# Altium schematic sheet top_blank.SchDoc
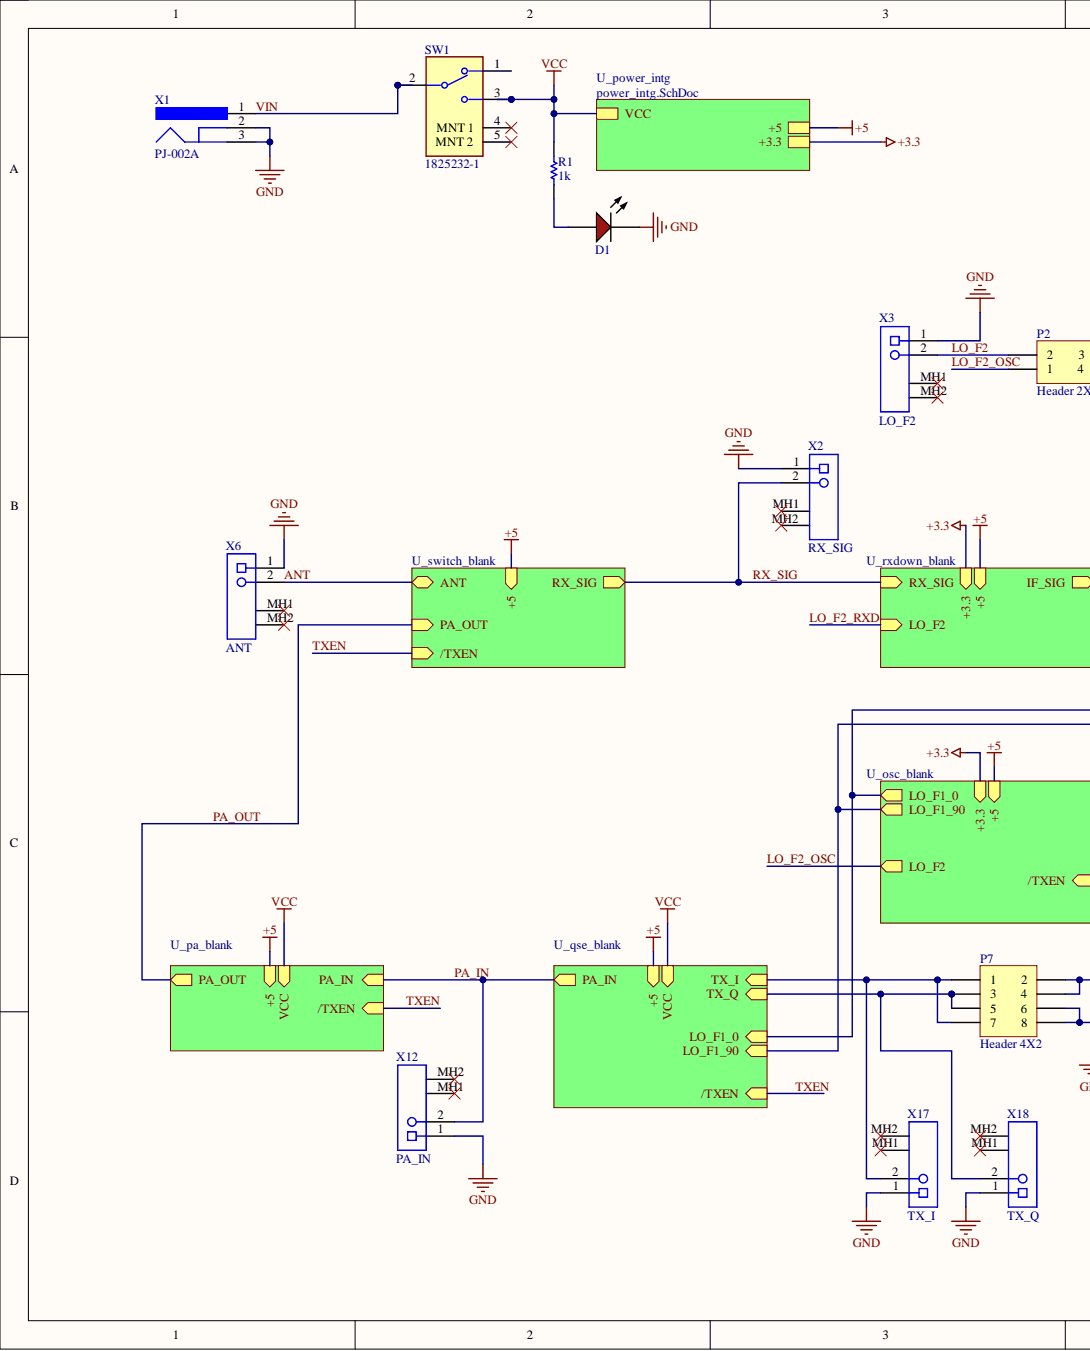
[diagram p0: top_blank.SchDoc, left]
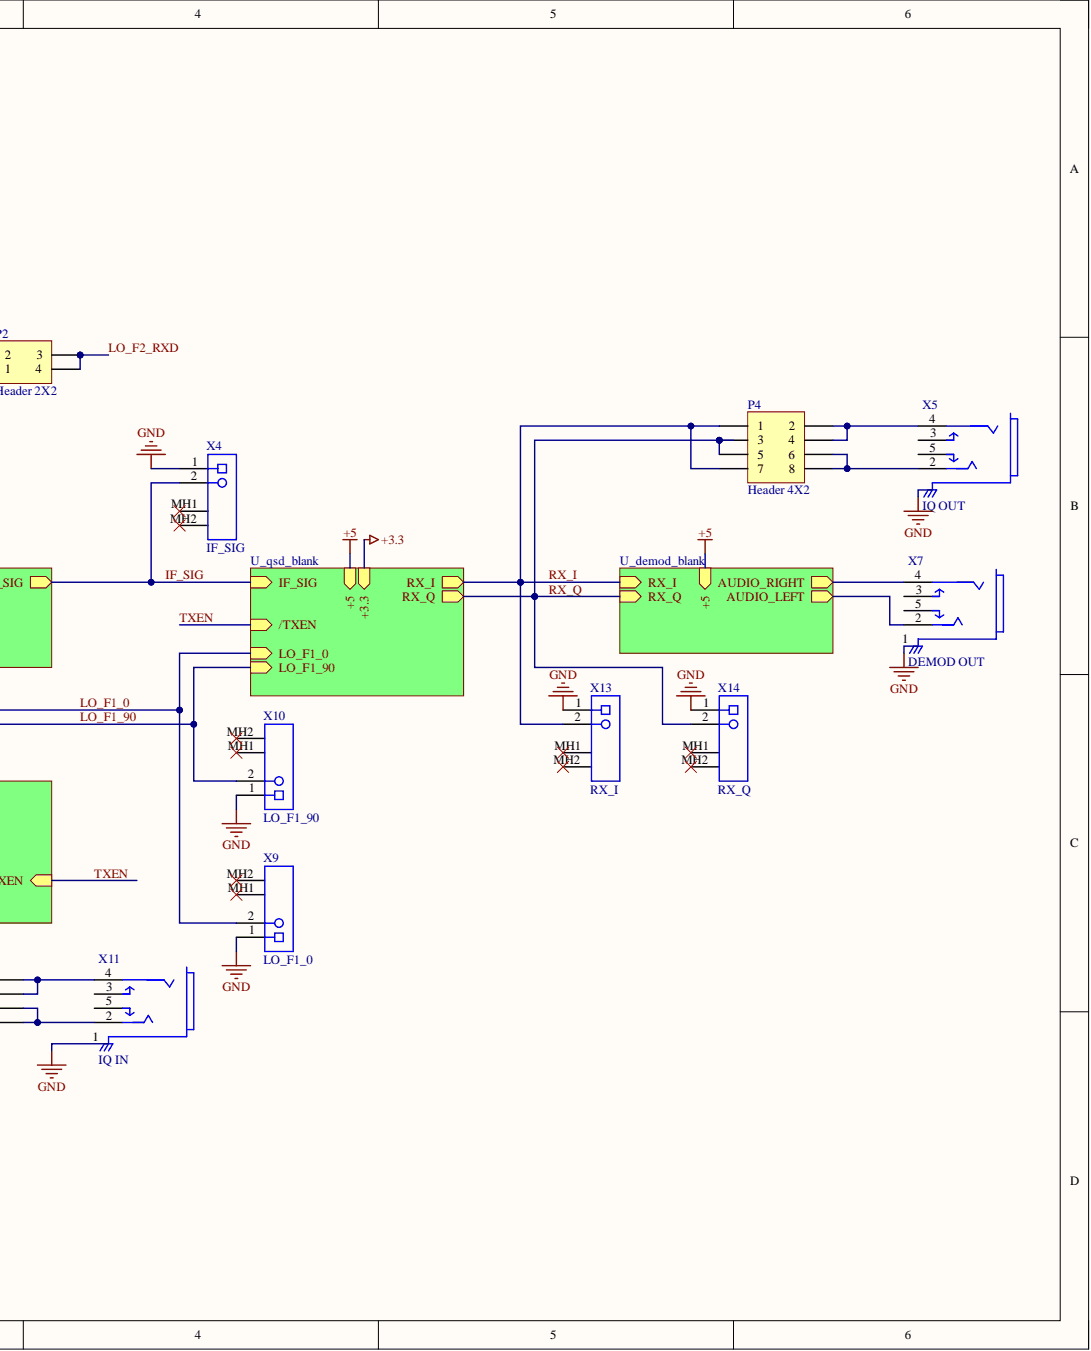
[diagram p1: top_blank.SchDoc, right]

## Parts
D1  HLMP-3301  [AVAG-HLMP-3301-2]  (value not drawn)
P2  Header 2X2  [HDR2X2]
P4  Header 4X2  [HDR2X4]
P7  Header 4X2  [HDR2X4]
R1  value 1k  [AXIAL-0.4]  (hidden comment: Res1)
SW1  1825232-1  [FP-1825232-1-MFG]
X1  PJ-002A  [CUI-PJ-002A_V]
X2  RX_SIG  [TECO-1-1337543-0_V]
X3  LO_F2  [TECO-1-1337543-0_V]
X4  IF_SIG  [TECO-1-1337543-0_V]
X5  IQ OUT  [FP-35RAPC4BV4-MFG]
X6  ANT  [TECO-1-1337543-0_V]
X7  DEMOD OUT  [FP-35RAPC4BV4-MFG]
X9  LO_F1_0  [TECO-1-1337543-0_V]
X10  LO_F1_90  [TECO-1-1337543-0_V]
X11  IQ IN  [FP-35RAPC4BV4-MFG]
X12  PA_IN  [TECO-1-1337543-0_V]
X13  RX_I  [TECO-1-1337543-0_V]
X14  RX_Q  [TECO-1-1337543-0_V]
X17  TX_I  [TECO-1-1337543-0_V]
X18  TX_Q  [TECO-1-1337543-0_V]

## Nets
GND: D1.1 X1.2 X1.3 X2.1 X3.1 X4.1 X5.1 X6.1 X7.1 X9.1 X10.1 X11.1 X12.1 X13.1 X14.1 X17.1 X18.1
VCC: R1.2 SW1.3
ANT: X6.2
IF_SIG: X4.2
LO_F1_0: X9.2
LO_F1_90: X10.2
LO_F2: P2.2 X3.2
LO_F2_OSC: P2.1
LO_F2_RXD: P2.3 P2.4
PA_IN: X12.2
RX_I: P4.1 P4.7 X13.2
RX_Q: P4.3 P4.5 X14.2
RX_SIG: X2.2
VIN: SW1.2 X1.1
NetD1_2: D1.2 R1.1
NetP4_2: P4.2 P4.4 X5.4
NetP4_6: P4.6 P4.8 X5.2
NetP7_1: P7.1 P7.7 X17.2
NetP7_2: P7.2 P7.4 X11.4
NetP7_3: P7.3 P7.5 X18.2
NetP7_6: P7.6 P7.8 X11.2
+3.3:
+5:
PA_OUT:
TXEN:

Not connected: SW1 1 4(MNT_1) 5(MNT_2); X2 MH1 MH2; X3 MH1 MH2; X4 MH1 MH2; X5 3 5; X6 MH1 MH2; X7 2 3 4 5; X9 MH1 MH2; X10 MH1 MH2; X11 3 5; X12 MH1 MH2; X13 MH1 MH2; X14 MH1 MH2; X17 MH1 MH2; X18 MH1 MH2

## Library data of the parts
D1 = CMP-2000-06411-1: T-1 3/4 (5 mm) Diffused LED Lamp, 1.9 V, -40 to 100 degC, 2-Pin THD, RoHS, Bulk; Manufacturer: Avago; Chip Material: GaP; DELETED: Compliant; Dominant Wave Length: 626nm; Emitting Color: Red; Forward Current: 25mA; Forward Voltage: 2.4V; High Brightness: No; Lens Type: ColoredDiffused; Lum Intensity: 5.4mcd; Main Category: StandardLED; Number of  Elements: 1; Peak Wavelength: 635nm; Power Dissipation: 85W; Product Depth (mm): 6.1mm; Product Height (mm): 9.19mm; Reverse Voltage: 5V; Shape Type: Circular; Test Current (It): 10mA; Type: Uni-Color; Viewing Angle: 60deg; Component Kind: Standard; Datasheet URL: http://www.avagotech.com/docs/AV02-1558EN; Datasheet Version: 03/2015; Lens Dimensions: 5.08x5.08x8.3mm; Library Name: Altium Content Vault; Luminous Intensity: 5.4mcd; Manufacturer URL: http://www.avagotech.com/; Mounting: ThroughHole; Mounting Technology: ThroughHole; Operating Temp Range: -40Cto100C; Operating Temperature Classification: Industrial; Package Description: 2-Pin Through Hole LED, Dia 5.85 mm; Package Reference: HLMP-3301; Package Type: T-13/4; Packaging: TapeandReel; Pin Count: 2; Product Length (mm): 6.1mm; Rad Hardened: No
P2 = Header 2X2: Header, 2-Pin, Dual row; Component Kind: Standard; LatestRevisionDate: 17-Jul-2002; LatestRevisionNote: Re-released for DXP Platform.; Library Name: Miscellaneous Connectors.IntLib; Signal Integrity: Connector; Publisher: Altium Limited
P4 P7 = Header 4X2: Header, 4-Pin, Dual row; Component Kind: Standard; LatestRevisionDate: 17-Jul-2002; LatestRevisionNote: Re-released for DXP Platform.; Library Name: Miscellaneous Connectors.IntLib; Signal Integrity: Connector; Publisher: Altium Limited
R1 = Res1: Resistor; Component Kind: Standard; LatestRevisionDate: 17-Jul-2002; LatestRevisionNote: Re-released for DXP Platform.; Library Name: Miscellaneous Devices.IntLib; PackageDescription: Axial Device, Thru-Hole; 2 Leads; 0.3 in Pin Spacing; PackageReference: AXIAL-0.3; Published: 8-Jun-2000; Signal Integrity: Res; Simulation: RESISTOR; Publisher: Altium Limited
SW1 = CMP-03405-000001-1: SWITCH SLIDE SPDT 200MA 30V; Manufacturer: TE Connectivity; Contact Current Rating: 0.2A; Contact Resistance: 20mΩ; Electromechanical Life: 10000Cycles; Operating Force: 200gf; Automotive: No; Category: Switch; Component Kind: Standard; ComponentLink2Description: Datasheet; ComponentLink2URL: https://www.te.com/commerce/DocumentDelivery/DDEController?Action=showdoc&DocId=Customer+Drawing%7F1825232%7FB2%7Fpdf%7FEnglish%7FENG_CD_1825232_B2.pdf%7F1825232-1; Device Class L1: Electromechanical; Device Class L2: Switches; Device Class L3: Slide Switches; Digikey Description: SWITCH SLIDE SPDT 200MA 30V; Height: 3.7mm; Lead Free: Yes; Library Name: Altium Content Vault; Manufacturer Part Number: 1825232-1; Mount: Through Hole; Mouser Description: Slide Switches SLIDE SWITCH SPDT Right Angle; Octopart: https://octopart.com/1825232-1-te+connectivity-45209882; Package: 1825232-1; RoHS: TRUE; Temperature: -20°C to +70°C; Temperature Range High: +70°C; Temperature Range Low: -20°C; Throw Configuration: SPDT; Voltage Rating Dc: 30V
X1 = CMP-2000-06895-1: Through Hole Right Angle DC Power Jack, 2.5 A, 2 mm Center Pin, 3 Position, -25 to 85 degC, RoHS, Bulk; Manufacturer: CUIInc.; Brand: CUI; Current Rating: 2.5A; Inside Contact Diameter: 2mm; Insulation Resistance: 50MOhms; Outside Contact Diameter: 6.5mm; Voltage Rating: 24V; Component Kind: Standard; Connector Type: DCPowerJack; Contact Gender: Male; Contact Material: Copper; Contact Plating: Nickel; Datasheet URL: http://www.cui.com/product/resource/pj-002a.pdf; Datasheet Version: Rev. 1.03, 04/2016; Factory Pack Quantity: 500; Flammability Rating: UL94V-0; Housing Material: PolybutyleneTerephthalate(PBT); Library Name: Altium Content Vault; Manufacturer URL: http://www.cui.com/; Mounting Angle: Right; Mounting Style: ThroughHole; Mounting Technology: ThroughHole; Operating Temperature Range: -25Cto+85C; Package Description: 3-Pin Through Hole Device, Body 14.4 x 9 mm, Height 11 mm; Package Reference: PJ-002A; Product: Jacks; Product Category: DCPowerConnectors; RoHS: True; Termination Style: ThroughHole
X2-X4 X6 X9 X10 X12-X14 X17 X18 = CMP-2000-05853-1: Female Connector, Pitch 2.54 mm, 1 x 2 Position, Height 15.8 mm, Tail Length 3.8 mm,   -55 to 85 degC, RoHS, Bulk; Manufacturer: TE Connectivity; Coaxial Termination: Solder; Connector Body Style: RightAngleJack; Connector Mounting: PCBMount; Connector Type: BNCCoaxial; Frequency Max: 4GHz; Impedance: 50ohm; SVHC: ToBeAdvised; Coaxial Cable Types: -; Component Kind: Standard; Contact Material: Brass; Contact Plating: GoldPlatedContacts; Datasheet URL: http://www.te.com/commerce/DocumentDelivery/DDEController?Action=showdoc&DocId=Customer+Drawing%7F1337543%7FG%7Fpdf%7FEnglish%7FENG_CD_1337543_G.pdf%7F1-1337543-0; Datasheet Version: Rev. G, 12/2012; Library Name: Altium Content Vault; Manufacturer URL: http://www.te.com/; Mounting Technology: ThroughHole; Package Description: Female Connector, Pitch 2.54 mm, 1 x 2 Position, Height 15.8 mm, Tail Length 3.8 mm; Package Reference: 1-1337543-0; Product Range: -
X5 X7 X11 = CMP-47983-000001-1: CONN JACK STEREO 3.5MM R/A; Manufacturer: Switchcraft; Manufacturer Part Number: 35RAPC4BV4; Obsolete Part: true; Conn Orientation: Right Angle; Number Of Contacts: 5; Automotive: No; Category: Conn; Component Kind: Standard; ComponentLink2Description: Datasheet; ComponentLink2URL: http://www.switchcraft.com/Drawings/35rapc__v4_cd.pdf; Contact Material: Silver; Device Class L1: Connectors; Device Class L2: Audio / Video Connectors; Device Class L3: unset; Digikey Description: CONN JACK STEREO 3.5MM R/A; Height: 0.495inch; Lead Free: Yes; Library Name: Altium Content Vault; Mouser Description: Phone Connectors 3.5MM VERT STEREO JK; Octopart: https://octopart.com/35rapc4bv4-switchcraft-223606; Package: 35RAPC4BV4; RoHS: TRUE
